# Altium schematic sheet Supplies.SchDoc(Supplies)
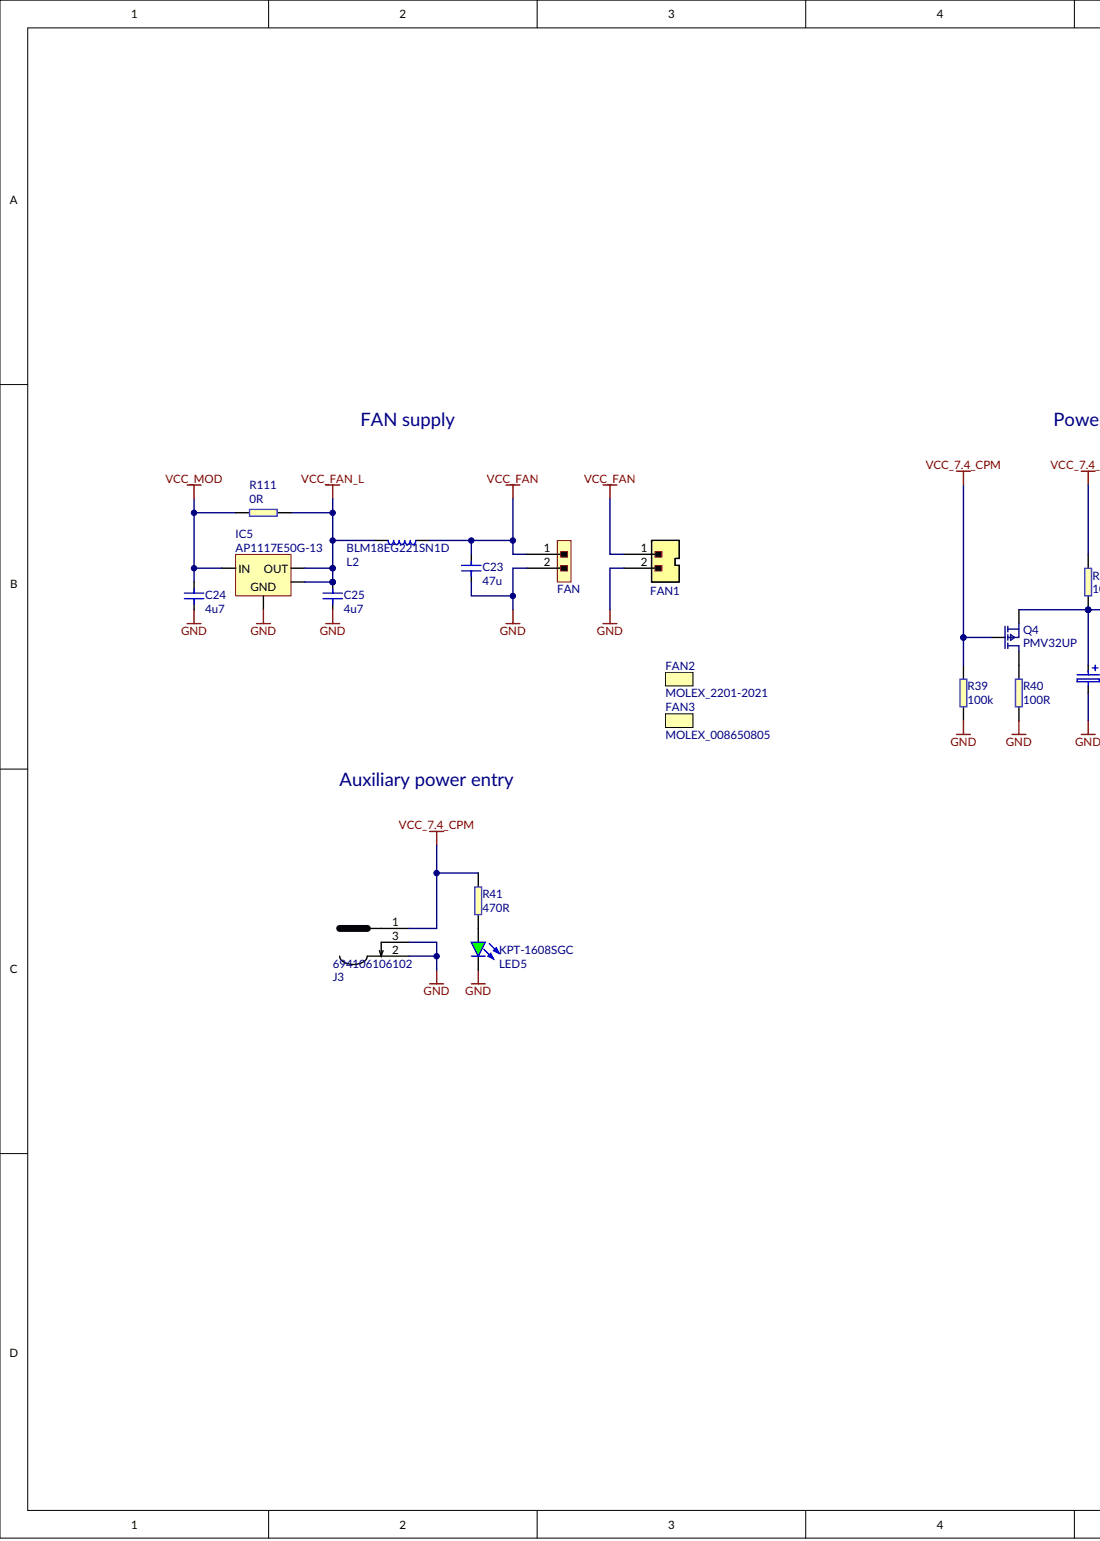
[diagram p0: Supplies.SchDoc, left]
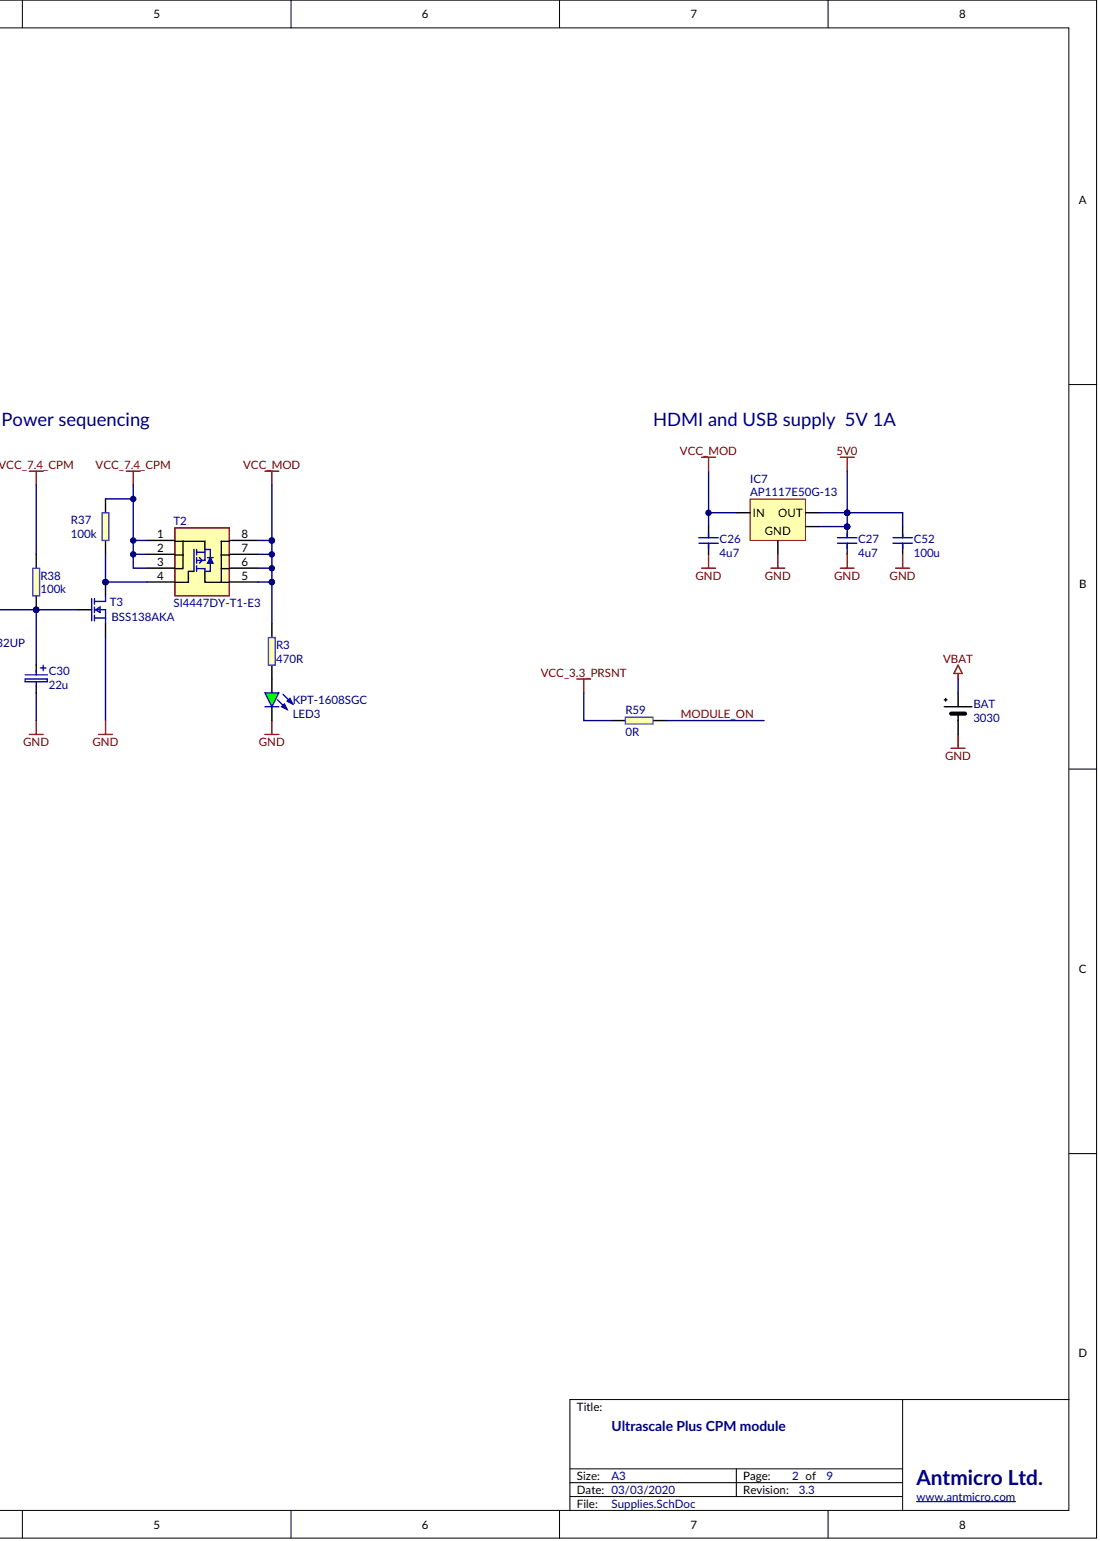
[diagram p1: Supplies.SchDoc, right]

## Parts
BAT  3030
C23  47u
C24  4u7
C25  4u7
C26  4u7
C27  4u7
C30  value 22u
C52  100u
FAN  *  (value not drawn)
FAN1  MX-22-23-2021  (value not drawn)
FAN2  MOLEX_2201-2021
FAN3  MOLEX_008650805
IC5  AP1117E50G-13
IC7  AP1117E50G-13
J3  694106106102
L2  BLM18EG221SN1D
LED3  KPT-1608SGC
LED5  KPT-1608SGC
Q4  PMV32UP
R3  470R
R37  100k
R38  100k
R39  100k
R40  100R
R41  470R
R59  0R
R111  0R
T2  SI4447DY-T1-E3
T3  BSS138AKA

## Nets
5V0: C27.2 C52.2 IC7.2(OUT) IC7.4(GND)
GND: BAT.- C23.1 C24.1 C25.1 C26.1 C27.1 C30.2 C52.1 FAN.2 FAN1.2 IC5.1 IC7.1 J3.2 J3.3 LED3.K LED5.K R39.1 R40.1 T3.2
VBAT: BAT.+
VCC_3.3_PRSNT: R59.1
VCC_7.4_CPM: J3.1 Q4.1 R37.2 R38.2 R39.2 R41.2 T2.1 T2.2 T2.3
VCC_FAN: C23.2 FAN.1 FAN1.1 L2.2
VCC_FAN_L: C25.2 IC5.2(OUT) IC5.4(GND) L2.1 R111.2
VCC_MOD: C24.2 C26.2 IC5.3(IN) IC7.3(IN) R3.2 R111.1 T2.5 T2.6 T2.7 T2.8
MODULE_ON: R59.2
NetC30_1: C30.1 Q4.2 R38.1 T3.1
NetLED3_A: LED3.A R3.1
NetLED5_A: LED5.A R41.1
NetQ4_3: Q4.3 R40.2
NetR37_1: R37.1 T2.4 T3.3

## Library data of the parts
BAT = (no symbol name): PartNumber: Keystone_CR1025; Manufacturer: Keystone; Value: 3030; Supplier1: Digi-Key; SupplierPartNumber1: 36-3030-ND
C23 = (no symbol name): Part Number: C_47u_1206; Manufacturer: MURATA; Part Symbol: GRM31CC80J476KE18L; Value: 47u; Supplier 1: Farnell; Supplier Part Number 1: 2218907
C24-C27 = (no symbol name): Part Number: C_4u7_0603; Manufacturer: MULTICOMP; Part Symbol: MC0603X475K6R3CT; Value: 4u7; Supplier 1: Farnell; Supplier Part Number 1: 2320811
C30 = (no symbol name): Part Number: C_22u_KEMET_C; Manufacturer: KEMET; Part Symbol: T491C226K025AT; Voltage: 25V; Supplier 1: Farnell; Supplier Part Number 1: 2283579
C52 = (no symbol name): Part Number: C_100u_0805; Manufacturer: MURATA; Part Symbol: GRM21BR60J107ME15L; Value: 100u; Supplier 1: Farnell; Supplier Part Number 1: 2494476
FAN = (no symbol name): Part Number: HEADER_1X2_male_angle
FAN1 = (no symbol name): Part Number: molex_2223-2021; Manufacturer: Molex; Part Symbol: 022232021 A-6373-02A222; Supplier Part Number 1: MX-22-23-2021; Value: MX-22-23-2021; Supplier 1: TME
FAN2 FAN3 = (no symbol name): Manufacturer: MOLEX; Supplier 1: Digi-Key
  FAN2: Part Number: MOLEX_2201-2021; Supplier Part Number 1: WM1575-ND; Value: MOLEX_2201-2021; Part Symbol: MOLEX_2201-2021
  FAN3: Part Number: MOLEX_008650805; Supplier Part Number 1: WM2756-ND; Value: MOLEX_008650805; Part Symbol: MOLEX_008650805
IC5 IC7 = (no symbol name): Manufacturer: Diodes; Value: AP1117E50G-13; Part Number: AP1117E50G-13; Supplier 1: Farnell; Supplier Part Number 1: 1825292; Part Symbol: AP1117E50G-13
J3 = (no symbol name): Part Number: WE-694106106102; Manufacturer: WURTH ELEKTRONIK; Pins number: 3; Supplier Part Number 1: 2472148; Supplier 2: Digi-Key; Supplier 3: Mouser; Supplier Part Number 2: 732-5929-1-ND; Supplier Part Number 3: 710-694106106102; Value: 694106106102; Supplier 1: Farnell; Part Symbol: 694106106102
L2 = (no symbol name): Manufacturer: MURATA; Rated Current: 2A; Part Number: BLM18EG221SN1D; Value: BLM18EG221SN1D; Supplier 1: Farnell; Supplier Part Number 1: 1515716; Part Symbol: BLM18EG221SN1D
LED3 LED5 = (no symbol name): Part Number: LED_G; Manufacturer: KINGBRIGHT; Value: KPT-1608SGC; Supplier 1: Farnell; Supplier Part Number 1: 2099223; Part Symbol: KPT-1608SGC
Q4 = (no symbol name): Manufacturer: NXP; Part Number: PMV32UP; Value: PMV32UP; Supplier 1: Farnell; Supplier Part Number 1: 1894624; Part Symbol: PMV32UP
R3 R41 = (no symbol name): Part Number: R_470R_0603; Manufacturer: BOURNS; Part Symbol: CR0603-JW-471GLF; Value: 470R; Supplier 1: Farnell; Supplier Part Number 1: 2008354
R37-R39 = (no symbol name): Part Number: R_100k_0603; Manufacturer: BOURNS; Part Symbol: CR0603-JW-104GLF; Tolerance: 5%; Value: 100k; Supplier 1: Farnell; Supplier Part Number 1: 2008366
R40 = (no symbol name): Part Number: R_100R_0603; Manufacturer: MULTICOMP; Part Symbol: MC0063W06035100R; Tolerance: 5%; Value: 100R; Supplier 1: Farnell; Supplier Part Number 1: 9331689
R59 = (no symbol name): Part Number: R_0R_0603; Manufacturer: BOURNS; Part Symbol: CR0603-J/-000ELF; Tolerance: 5%; Value: 0R; Supplier 1: Farnell; Supplier Part Number 1: 2008343
R111 = (no symbol name): PartNumber: R_0R_0805; Manufacturer: VISHAY; Tolerance: 5%; Value: 0R; Supplier1: Farnell; SupplierPartNumber1: 1469846
T2 = (no symbol name): Manufacturer: VISHAY; Part Number: SI4447DY-T1-E3; Value: SI4447DY-T1-E3; Supplier 1: Farnell; Supplier Part Number 1: 1690122; Part Symbol: SI4447DY-T1-E3
T3 = (no symbol name): Part Number: BSS138; Manufacturer: NXP; Value: BSS138AKA; Supplier 1: Farnell; Supplier Part Number 1: 2357125; Part Symbol: BSS138AKA
